# Altium schematic sheet RGB_Led_StripSCH.SchDoc
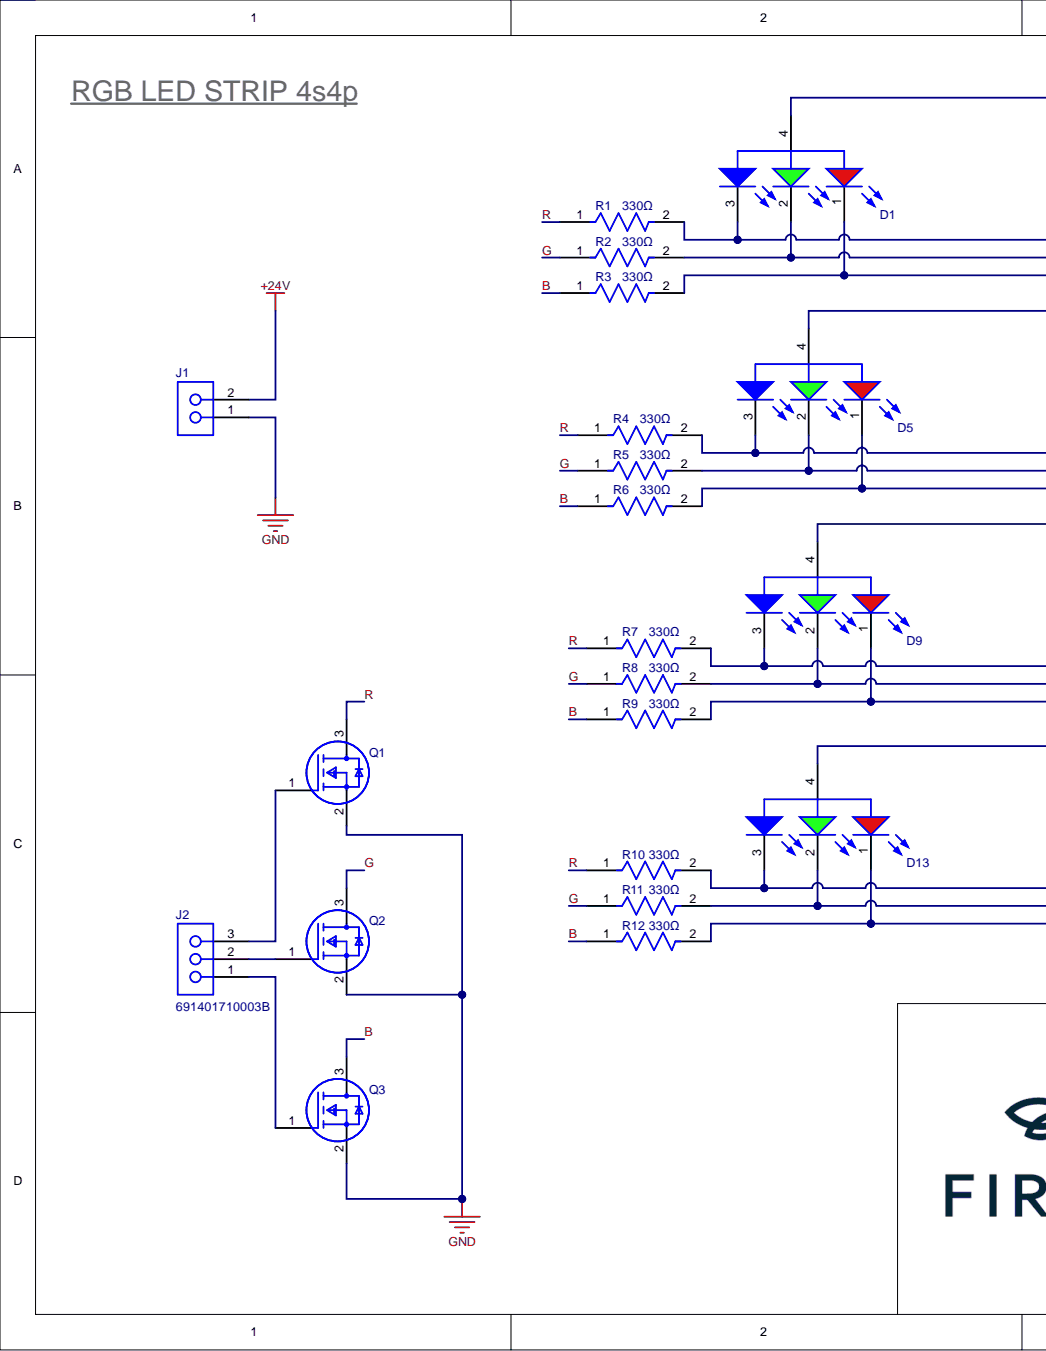
[diagram p0: RGB_Led_StripSCH.SchDoc, left]
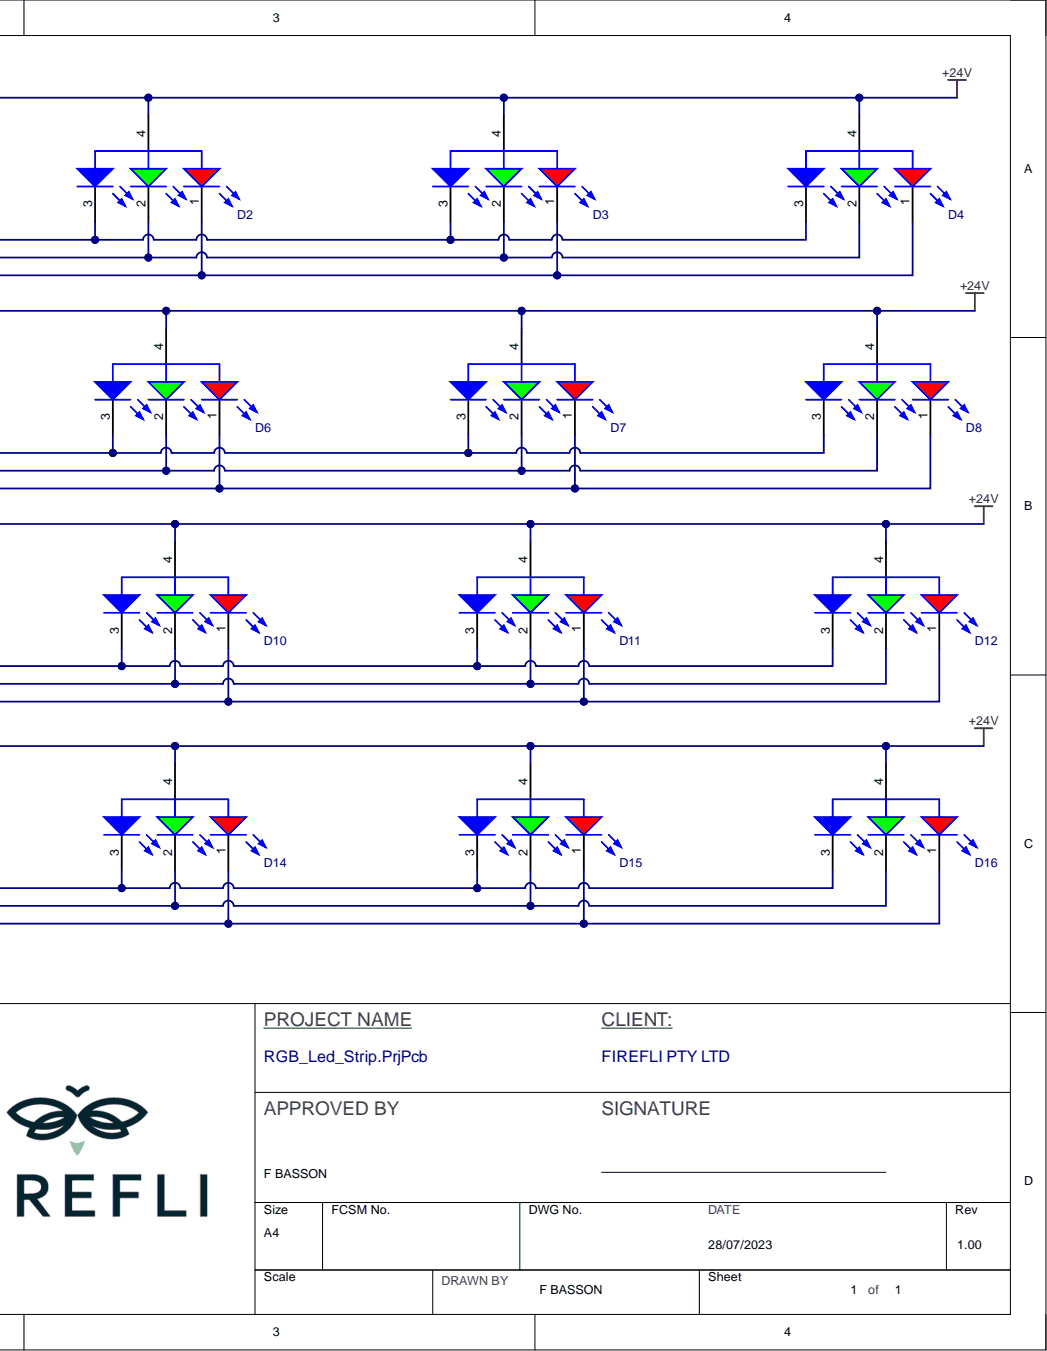
[diagram p1: RGB_Led_StripSCH.SchDoc, right]

## Parts
D1  150121M173000  [WL-SFCW_1210_L3.2W2.7H1.1]  (value not drawn)
D2  150121M173000  [WL-SFCW_1210_L3.2W2.7H1.1]  (value not drawn)
D3  150121M173000  [WL-SFCW_1210_L3.2W2.7H1.1]  (value not drawn)
D4  150121M173000  [WL-SFCW_1210_L3.2W2.7H1.1]  (value not drawn)
D5  150121M173000  [WL-SFCW_1210_L3.2W2.7H1.1]  (value not drawn)
D6  150121M173000  [WL-SFCW_1210_L3.2W2.7H1.1]  (value not drawn)
D7  150121M173000  [WL-SFCW_1210_L3.2W2.7H1.1]  (value not drawn)
D8  150121M173000  [WL-SFCW_1210_L3.2W2.7H1.1]  (value not drawn)
D9  150121M173000  [WL-SFCW_1210_L3.2W2.7H1.1]  (value not drawn)
D10  150121M173000  [WL-SFCW_1210_L3.2W2.7H1.1]  (value not drawn)
D11  150121M173000  [WL-SFCW_1210_L3.2W2.7H1.1]  (value not drawn)
D12  150121M173000  [WL-SFCW_1210_L3.2W2.7H1.1]  (value not drawn)
D13  150121M173000  [WL-SFCW_1210_L3.2W2.7H1.1]  (value not drawn)
D14  150121M173000  [WL-SFCW_1210_L3.2W2.7H1.1]  (value not drawn)
D15  150121M173000  [WL-SFCW_1210_L3.2W2.7H1.1]  (value not drawn)
D16  150121M173000  [WL-SFCW_1210_L3.2W2.7H1.1]  (value not drawn)
J1  691401710002B  [691401710002B]  (value not drawn)
J2  691401710003B  [691401710003B]
Q1  BSS138P,215  [FP-SOT23-IPC_C]  (value not drawn)
Q2  BSS138P,215  [FP-SOT23-IPC_C]  (value not drawn)
Q3  BSS138P,215  [FP-SOT23-IPC_C]  (value not drawn)
R1  value 330Ω  [FP-RK73H1J-IPC_B]  (hidden comment: RK73H1JLTD3300F)
R2  value 330Ω  [FP-RK73H1J-IPC_B]  (hidden comment: RK73H1JLTD3300F)
R3  value 330Ω  [FP-RK73H1J-IPC_B]  (hidden comment: RK73H1JLTD3300F)
R4  value 330Ω  [FP-RK73H1J-IPC_B]  (hidden comment: RK73H1JLTD3300F)
R5  value 330Ω  [FP-RK73H1J-IPC_B]  (hidden comment: RK73H1JLTD3300F)
R6  value 330Ω  [FP-RK73H1J-IPC_B]  (hidden comment: RK73H1JLTD3300F)
R7  value 330Ω  [FP-RK73H1J-IPC_B]  (hidden comment: RK73H1JLTD3300F)
R8  value 330Ω  [FP-RK73H1J-IPC_B]  (hidden comment: RK73H1JLTD3300F)
R9  value 330Ω  [FP-RK73H1J-IPC_B]  (hidden comment: RK73H1JLTD3300F)
R10  value 330Ω  [FP-RK73H1J-IPC_B]  (hidden comment: RK73H1JLTD3300F)
R11  value 330Ω  [FP-RK73H1J-IPC_B]  (hidden comment: RK73H1JLTD3300F)
R12  value 330Ω  [FP-RK73H1J-IPC_B]  (hidden comment: RK73H1JLTD3300F)

## Nets
+24V: D1.4 D2.4 D3.4 D4.4 D5.4 D6.4 D7.4 D8.4 D9.4 D10.4 D11.4 D12.4 D13.4 D14.4 D15.4 D16.4 J1.2
GND: J1.1 Q1.2 Q2.2 Q3.2
B: Q3.3 R3.1 R6.1 R9.1 R12.1
G: Q2.3 R2.1 R5.1 R8.1 R11.1
R: Q1.3 R1.1 R4.1 R7.1 R10.1
NetD1_1: D1.1 D2.1 D3.1 D4.1 R3.2
NetD1_2: D1.2 D2.2 D3.2 D4.2 R2.2
NetD1_3: D1.3 D2.3 D3.3 D4.3 R1.2
NetD5_1: D5.1 D6.1 D7.1 D8.1 R6.2
NetD5_2: D5.2 D6.2 D7.2 D8.2 R5.2
NetD5_3: D5.3 D6.3 D7.3 D8.3 R4.2
NetD9_1: D9.1 D10.1 D11.1 D12.1 R9.2
NetD9_2: D9.2 D10.2 D11.2 D12.2 R8.2
NetD9_3: D9.3 D10.3 D11.3 D12.3 R7.2
NetD13_1: D13.1 D14.1 D15.1 D16.1 R12.2
NetD13_2: D13.2 D14.2 D15.2 D16.2 R11.2
NetD13_3: D13.3 D14.3 D15.3 D16.3 R10.2
NetJ2_1: J2.1 Q3.1
NetJ2_2: J2.2 Q2.1
NetJ2_3: J2.3 Q1.1

## Library data of the parts
D1-D16 = CMP-03913-82270977-1: 150121M173000 WL-SFCW SMT Full-color Chip LED waterclear; Dominant Wavelength: 525nm; Forward Current: 60mA; Forward Voltage: 2V; Luminous Intensity: 70mcd; Max Reverse Voltage (DC): 5V; Peak Wavelength: 468nm; Power Dissipation: 48mW; Viewing Angle: 120°; Component Kind: Standard; Height: 1.1mm; Length: 3.2mm; Library Name: Altium Content Vault; Max Operating Temperature: 85°C; Min Operating Temperature: -40°C; NexarPartId: 82270977; Packaging: Tape and Reel; RoHS: Compliant; Width: 2.7mm
J1 = CMP-039-000447-1: WR-TBL Series 401B Horizontal Entry, Through Hole, pitch 5mm, 2p; Manufacturer: Wurth Elektronik; Modularity: No; PCB/Cable/Panel: PCB; Pin Number: 2; Rated Current: 10A; Working Voltage: 300V; Application: Screwless; Category: Terminal Blocks; Component Kind: Standard; Component Type: Standard; ComponentLink1Description: Manufacturer Link; ComponentLink1URL: https://www.we-online.com/redexpert/article/691401710002B?ad; ComponentLink2Description: Datasheet; ComponentLink2URL: https://www.we-online.com/redexpert/spec/691401710002B?ad; Design Item ID: 691401710002B; Manufacturer Part Number: 691401710002B; Match Code: WR-TBL; Mount: Through Hole; Operating Temperature Max: 105°C; Operating Temperature Min: -40°C; Orientation: Horizontal; Packaging: Box; Pin Count: 2; Pitch: 5mm; Source: WR-TBL.SchLib; Library Name: Friedl_Basson
J2 = CMP-039-000448-1: WR-TBL Series 401B Horizontal Entry, Through Hole, pitch 5mm, 3p; Manufacturer: Wurth Elektronik; Modularity: No; PCB/Cable/Panel: PCB; Pin Number: 3; Rated Current: 10A; Working Voltage: 300V; Application: Screwless; Category: Terminal Blocks; Component Kind: Standard; Component Type: Standard; ComponentLink1Description: Manufacturer Link; ComponentLink1URL: https://www.we-online.com/redexpert/article/691401710003B?ad; ComponentLink2Description: Datasheet; ComponentLink2URL: https://www.we-online.com/redexpert/spec/691401710003B?ad; Design Item ID: 691401710003B; Manufacturer Part Number: 691401710003B; Match Code: WR-TBL; Mount: Through Hole; Operating Temperature Max: 105°C; Operating Temperature Min: -40°C; Orientation: Horizontal; Packaging: Box; Pin Count: 3; Pitch: 5mm; Source: WR-TBL.SchLib; Library Name: Friedl_Basson
Q1-Q3 = CMP-013-00034-1: BSS138P Series 60 V 1.6 Ohm 350 mW 0.72 nC N-Channel SMT TrenchMOS FET - SOT-23; Component Kind: Standard; Library Name: Friedl_Basson
R1-R12 = CMP-009-00223-1: Thick Film Resistors 1/10watts 330ohms 1%; Component Kind: Standard; Library Name: Friedl_Basson
